# Altium schematic sheet Sheet1.SchDoc
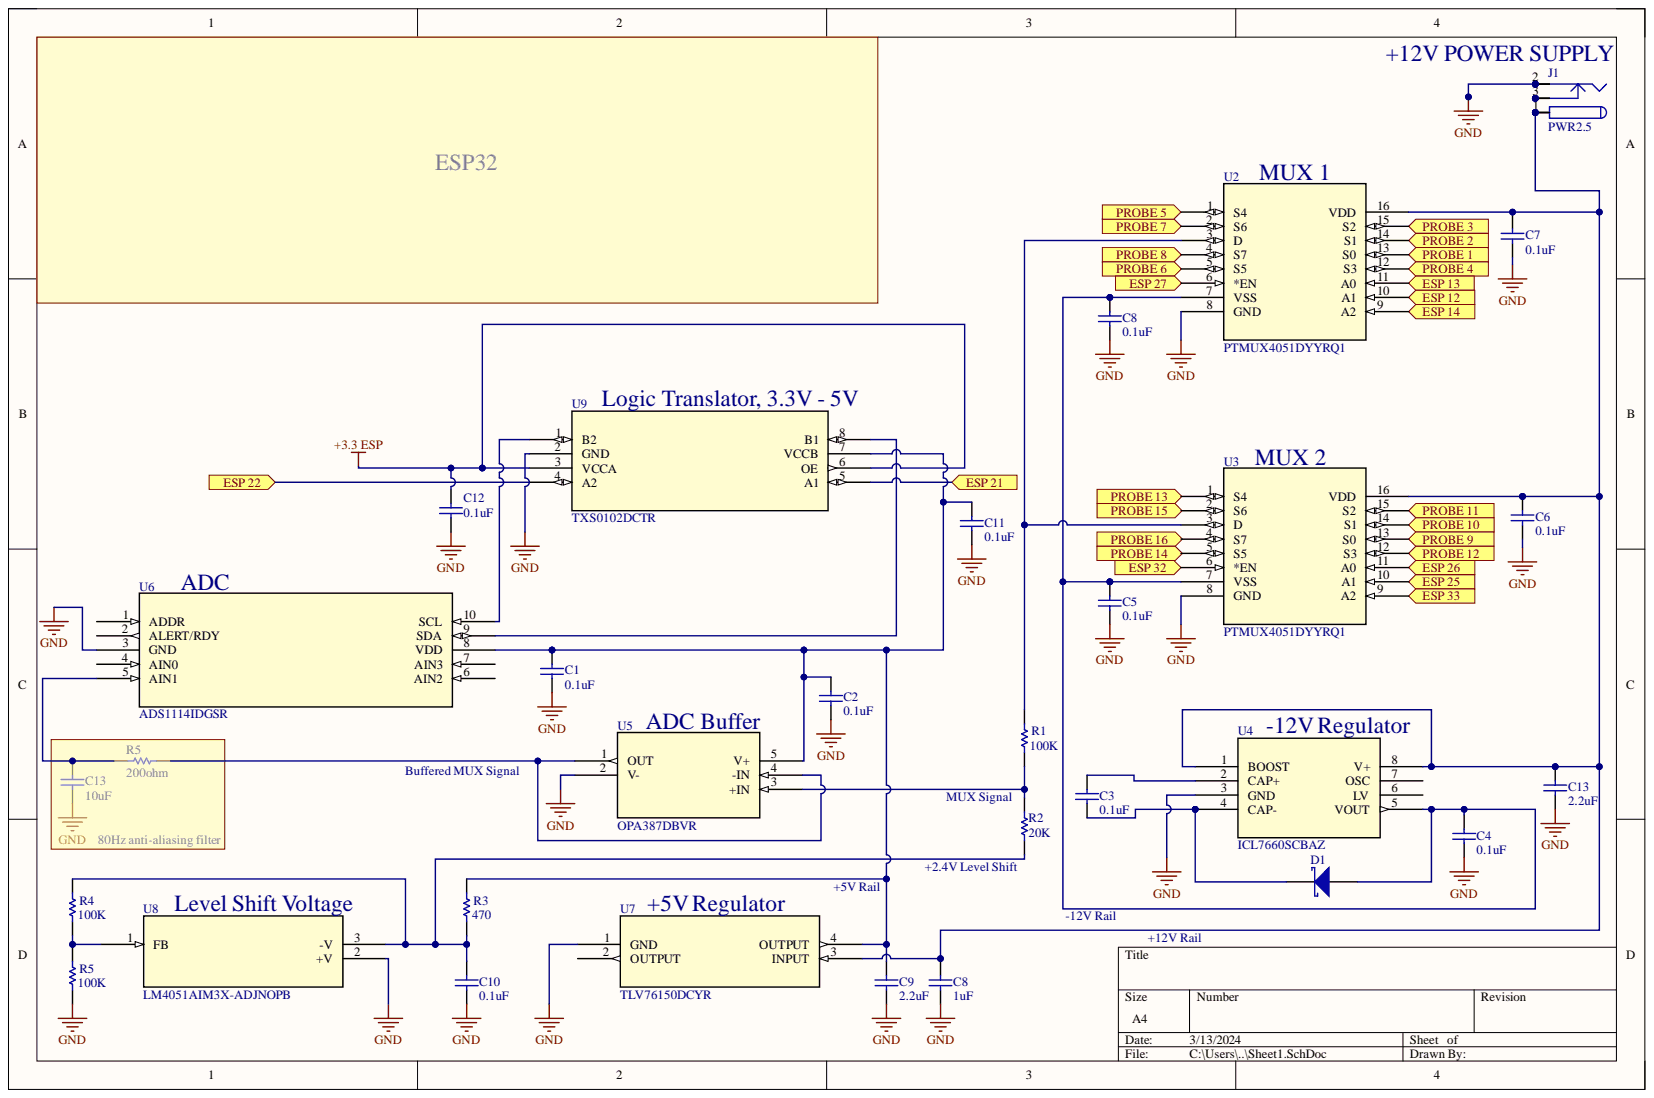
[diagram p0: Sheet1.SchDoc]

## Parts
C1  value 0.1uF  (hidden comment: Capacitor)
C2  value 0.1uF  (hidden comment: Capacitor)
C3  value 0.1uF  (hidden comment: Capacitor)
C4  value 0.1uF  (hidden comment: Capacitor)
C5  value 0.1uF  (hidden comment: Capacitor)
C6  value 0.1uF  (hidden comment: Capacitor)
C7  value 0.1uF  (hidden comment: Capacitor)
C8  value 1uF  (hidden comment: Capacitor)
C8  value 0.1uF  (hidden comment: Capacitor)
C9  value 2.2uF  (hidden comment: Capacitor)
C10  value 0.1uF  (hidden comment: Capacitor)
C11  value 0.1uF  (hidden comment: Capacitor)
C12  value 0.1uF  (hidden comment: Capacitor)
C13  value 2.2uF  (hidden comment: Capacitor)
C13  value 10uF  (hidden comment: Capacitor)
D1  Shottky Diode  (value not drawn)
J1  PWR2.5  [KLD-0202]
R1  value 100K  (hidden comment: Resistor)
R2  value 20K  (hidden comment: Resistor)
R3  value 470  (hidden comment: Resistor)
R4  value 100K  (hidden comment: Resistor)
R5  value 200ohm  (hidden comment: Resistor)
R5  value 100K  (hidden comment: Resistor)
U2  PTMUX4051DYYRQ1  [SOT_YYRQ1_TEX]
U3  PTMUX4051DYYRQ1  [SOT_YYRQ1_TEX]
U4  ICL7660SCBAZ  [SOIC8_4X5_INR]
U5  OPA387DBVR  [SOT23_OPA387_TEX]
U6  ADS1114IDGSR  [DGS10]
U7  TLV76150DCYR  [SOT_8DCYR_TEX]
U8  LM4051AIM3X-ADJNOPB  [MF03A_TEX]
U9  TXS0102DCTR  [DCT8]

## Ports
ESP 12, ESP 13, ESP 14, ESP 21, ESP 22, ESP 25, ESP 26, ESP 27, ESP 32, ESP 33, PROBE 1, PROBE 2, PROBE 3, PROBE 4, PROBE 5, PROBE 6, PROBE 7, PROBE 8, PROBE 9, PROBE 10, PROBE 11, PROBE 12, PROBE 13, PROBE 14, PROBE 15, PROBE 16

## Nets
+3.3 ESP: C12.2 U9.3(VCCA) U9.6(OE)
GND: C1.1 C2.1 C4.1 C5.1 C6.1 C7.1 C8.1 C9.1 C10.1 C11.1 C12.1 C13.1 J1.2 J1.2A J1.2B R5.1 U2.8(GND) U3.8(GND) U4.3(GND) U5.2(V-) U6.3(GND) U7.1(GND) U8.2 U9.2(GND)
ESP 12: U2.10(A1) ; port ESP 12
ESP 13: U2.11(A0) ; port ESP 13
ESP 14: U2.9(A2) ; port ESP 14
ESP 21: U9.5(A1) ; port ESP 21
ESP 22: U9.4(A2) ; port ESP 22
ESP 25: U3.10(A1) ; port ESP 25
ESP 26: U3.11(A0) ; port ESP 26
ESP 33: U3.9(A2) ; port ESP 33
PROBE 1: U2.13(S0) ; port PROBE 1
PROBE 2: U2.14(S1) ; port PROBE 2
PROBE 3: U2.15(S2) ; port PROBE 3
PROBE 4: U2.12(S3) ; port PROBE 4
PROBE 5: U2.1(S4) ; port PROBE 5
PROBE 9: U3.13(S0) ; port PROBE 9
PROBE 10: U3.14(S1) ; port PROBE 10
PROBE 11: U3.15(S2) ; port PROBE 11
PROBE 12: U3.12(S3) ; port PROBE 12
NetC1_2: C1.2 C2.2 C9.2 C11.2 R3.2 U5.5(V+) U6.8(VDD) U7.4(OUTPUT) U9.7(VCCB)
NetC3_1: C3.1 D1.2 U4.4(CAP-)
NetC3_2: C3.2 U4.2(CAP+)
NetC4_2: C4.2 C5.2 C8.2 D1.1 U2.7(VSS) U3.7(VSS) U4.5(VOUT)
NetC6_2: C6.2 C7.2 C8.2 C13.2 J1.1 J1.1A J1.1B U2.16(VDD) U3.16(VDD) U4.1(BOOST) U4.8(V+) U7.3(INPUT)
NetC10_2: C10.2 R2.1 R3.1 R4.2 U8.3
NetC13_2: C13.2 R5.1 U6.5(AIN1)
NetJ1_3: J1.3 J1.3A J1.3B
NetR1_1: R1.1 R2.2 U5.3(+IN)
NetR1_2: R1.2 U2.3(D) U3.3(D)
NetR4_1: R4.1 R5.2 U8.1
NetR5_2: R5.2 U5.1(OUT) U5.4(-IN)
NetU6_9: U6.9(SDA) U9.8(B1)
NetU6_10: U6.10(SCL) U9.1(B2)
N00001: ; port PROBE 8
N00002: ; port ESP 32
N00003: ; port PROBE 13
N00004: ; port PROBE 14
N00005: ; port PROBE 6
N00006: ; port PROBE 15
N00007: ; port ESP 27
N00008: ; port PROBE 16
N00009: ; port PROBE 7

Not connected: U2 2(S6) 4(S7) 5(S5) 6(*EN); U3 1(S4) 2(S6) 4(S7) 5(S5) 6(*EN); U4 6(LV) 7(OSC); U6 1(ADDR) 2(ALERT/RDY) 4(AIN0) 6(AIN2) 7(AIN3); U7 2(OUTPUT)

## Library data of the parts
C1-C8 C8-C13 C13 = Capacitor: SimulationGenericComponent: True; Component Kind: Standard; Description: Capacitor; Library Name: Simulation Generic Components.IntLib; Simulation: C_Capacitor_Default
D1 = Shottky Diode: AF: 1; BV: 0; BV_MAX: 1e99; EG: 1.11; FC: 0.5; IBV: 0.001; IS: 1e-14; MJSW: 0.33; NTUN: 30; SimulationGenericComponent: True; TNOM: 27; XTI: 3; CJO: 0; CJP: 0; Component Kind: Standard; CTA: 0; CTP: 0; Description: Shottky Diode; FCS: 0.5; FV_MAX: 1e99; IKF: 0; IKR: 0; ISR: 1e-14; JSW: 0; JTUN: 0; JTUNSW: 0; KEG: 1; KF: 0; LEVEL: 1; Library Name: Simulation Generic Components.IntLib; N: 1; NBV: 1; NR: 1; NS: 1; PHP: 1; RS: 0; Simulation: diode; TCV: 0; TLEV: 0; TLEVC: 0; TM1: 0; TM2: 0; TPB: 0; TPHP: 0; TRS: 0; TRS2: 0; TT: 0; TTT1: 0; TTT2: 0; VJ: 1; XTITUN: 3
J1 = PWR2.5: Low Voltage Power Supply Connector; Component Kind: Standard; ComponentLink1Description: Manufacturer Link; ComponentLink1URL: http://domino.kycon.com/internet/Products.html; DatasheetDocument: 09-May-2002; Library Name: Miscellaneous Connectors.IntLib; Published: 17-Dec-2003; Signal Integrity: Connector; Publisher: Altium Limited
R1-R5 R5 = Resistor: SimulationGenericComponent: True; TC1: 0; Component Kind: Standard; Description: Resistor; Library Name: Simulation Generic Components.IntLib; Simulation: R_Resistor_Default; TC2: 0
U2 U3 = PTMUX4051DYYRQ1: No Description Available; Mfr_Name: Texas Instruments; Component Kind: Standard; Library Name: PTMUX4051DYYRQ1.SchLib; RefDes: RefDes; Type: Interface
U4 = ICL7660SCBAZ: No Description Available; Mfr_Name: Renesas Electronics; Component Kind: Standard; Library Name: ICL7660SCBAZ.SchLib; RefDes: RefDes; Type: Type
U5 = OPA387DBVR: No Description Available; Mfr_Name: Texas Instruments; Component Kind: Standard; Library Name: OPA387DBVR.SchLib; RefDes: RefDes; Type: Type
U6 = ADS1114IDGSR: No Description Available; Mfr_Name: Texas Instruments; Component Kind: Standard; Library Name: ADS1114IDGSR.SchLib; RefDes: RefDes; Type: Type
U7 = TLV76150DCYR: No Description Available; Mfr_Name: Texas Instruments; Component Kind: Standard; Library Name: TLV76150DCYR.SchLib; RefDes: RefDes; Type: Power Management
U8 = LM4051AIM3X-ADJNOPB: No Description Available; Mfr_Name: Texas Instruments; Component Kind: Standard; Library Name: LM4051AIM3X-ADJNOPB.SchLib; RefDes: RefDes; Type: Type
U9 = TXS0102DCTR: No Description Available; Mfr_Name: Texas Instruments; Component Kind: Standard; Library Name: TXS0102DCTR.SchLib; RefDes: RefDes; Type: Type
